# Altium schematic sheet 8051_core.SchDoc(8051_core)
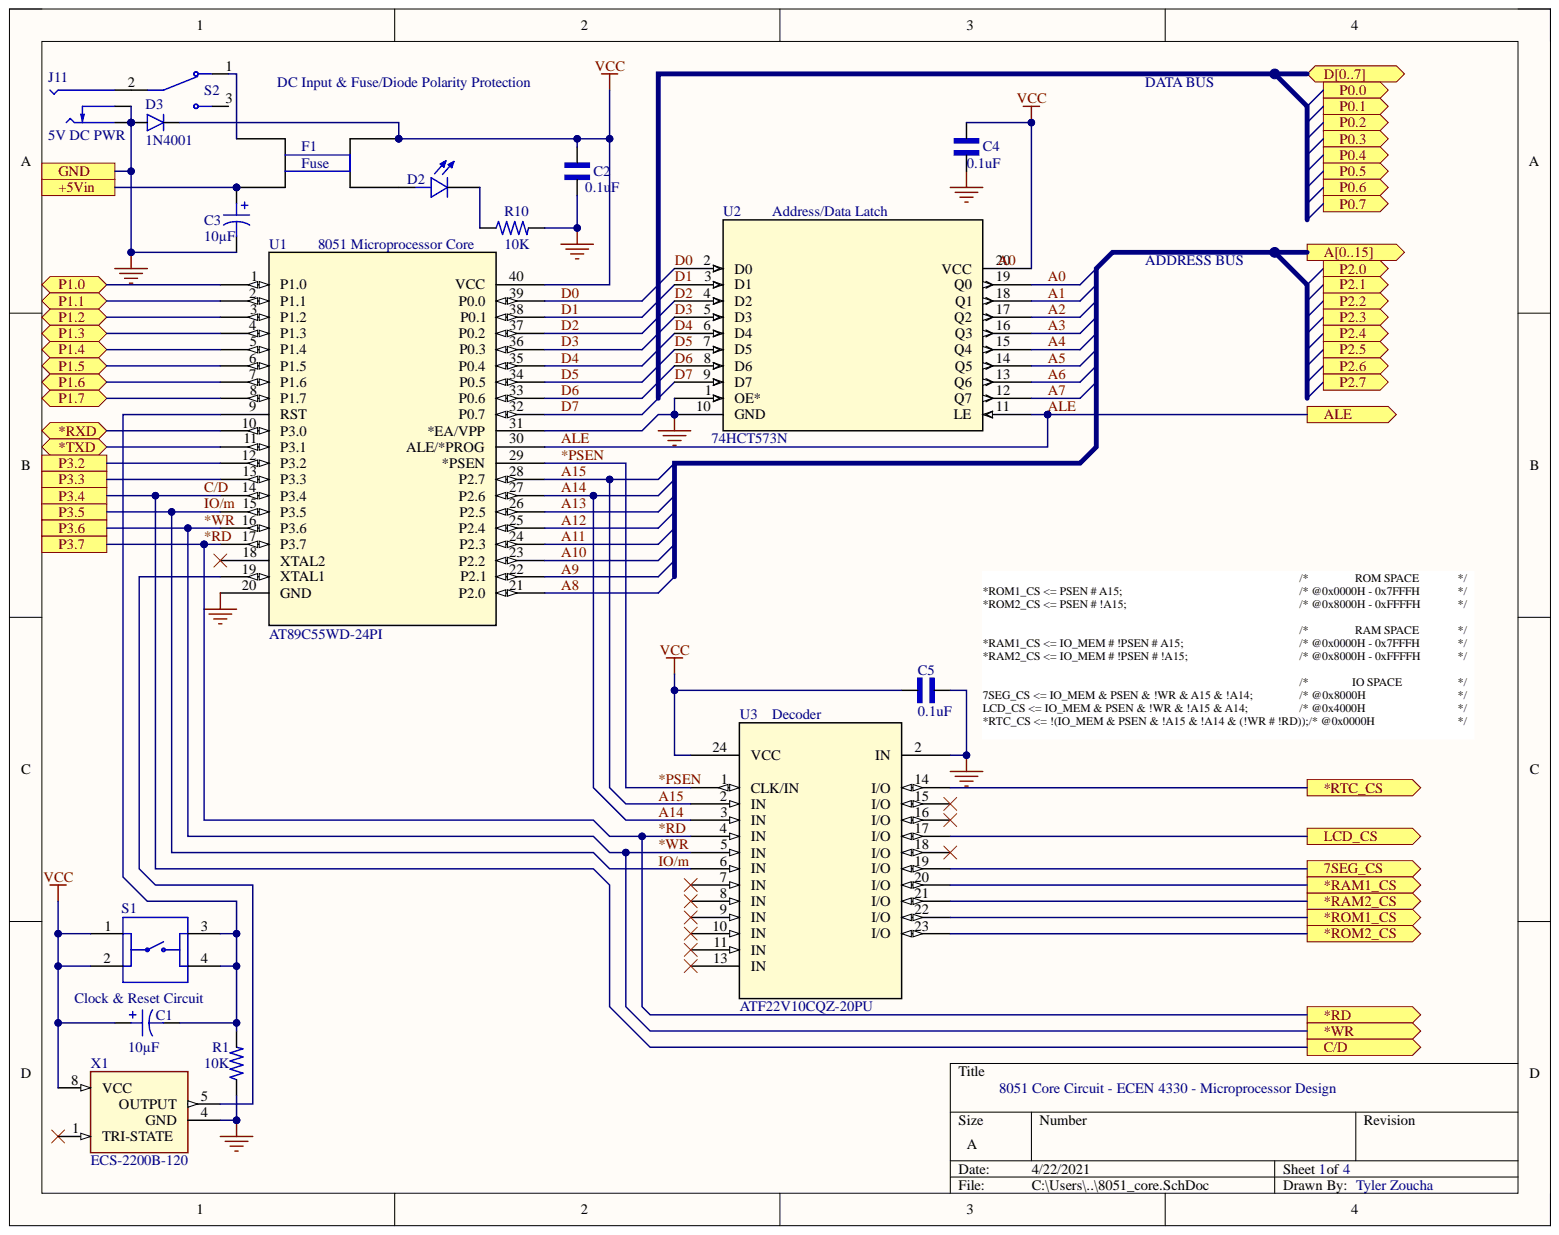
[diagram p0: 8051_core.SchDoc]

## Parts
C1  10µF  [CAP TH ALUM ELEC D5.00mm S2.50mm H12.50mm]
C2  0.1uF  [CAP_RDER73A681K2M1H03A]
C3  10µF  [CAP TH ALUM ELEC D5.00mm S2.50mm H12.50mm]
C4  0.1uF  [CAP_RDER73A681K2M1H03A]
C5  0.1uF  [CAP_RDER73A681K2M1H03A]
D2  151054RS03000  [LED_151054RS03000]  (value not drawn)
D3  1N4001  [DO41-10]
F1  Fuse  [Fuse]
J11  5V DC PWR  [SWITCHCRAFT_RAPC712X]
R1  10K  [TO508P1060X500X1900-2]
R10  10K  [TO508P1060X500X1900-2]
S1  1825910-6  [SW_1825910-6-4]  (value not drawn)
S2  100SP1T1B4M2QE  [SW_100SP1T1B4M2QE]  (value not drawn)
U1  AT89C55WD-24PI  [PDIP40_600MC_MCH]
U2  74HCT573N  [SOT146-1_NEX]
U3  ATF22V10CQZ-20PU  [24P3_ATM]
X1  ECS-2200B-120  [OSC_ECS-2200B-120]

## Ports
7SEG_CS, *RAM1_CS, *RAM2_CS, *RD, *ROM1_CS, *ROM2_CS, *RTC_CS, *RXD, *TXD, *WR, +5Vin, A0, A1, A2, A3, A4, A5, A6, A7, A8, A9, A10, A11, A12, A13, A14, A15, A[0..15], ALE, C/D, D0, D1, D2, D3, D4, D5, D6, D7, D[0..7], GND, LCD_CS, P0.0, P0.1, P0.2, P0.3, P0.4, P0.5, P0.6, P0.7, P1.0, P1.1, P1.2, P1.3, P1.4, P1.5, P1.6, P1.7, P2.0, P2.1, P2.2, P2.3, P2.4, P2.5, P2.6, P2.7, P3.2, P3.3, P3.4, P3.5, P3.6, P3.7

## Nets
VCC: C1.1 C2.2 C4.2 C5.1 D3.C F1.3 S1.1 S1.2 U1.40(VCC) U2.20(VCC) U3.24(VCC) X1.8(VCC)
7SEG_CS: U3.19(I/O) ; port 7SEG_CS
*PSEN: U1.29(*PSEN) U3.1(CLK/IN)
*RAM1_CS: U3.20(I/O) ; port *RAM1_CS
*RAM2_CS: U3.21(I/O) ; port *RAM2_CS
*RD: U1.17(P3.7) U3.4(IN) ; port *RD, port P3.7
*ROM1_CS: U3.22(I/O) ; port *ROM1_CS
*ROM2_CS: U3.23(I/O) ; port *ROM2_CS
*RTC_CS: U3.14(I/O) ; port *RTC_CS
*RXD: U1.10(P3.0) ; port *RXD
*TXD: U1.11(P3.1) ; port *TXD
*WR: U1.16(P3.6) U3.5(IN) ; port *WR, port P3.6
+5Vin: C3.1 F1.1 ; port +5Vin
A0: U2.19(Q0) ; port A[0..15]  [also labelled ]
A1: U2.18(Q1) ; port A[0..15]  [also labelled ]
A2: U2.17(Q2) ; port A[0..15]  [also labelled ]
A3: U2.16(Q3) ; port A[0..15]  [also labelled ]
A4: U2.15(Q4) ; port A[0..15]  [also labelled ]
A5: U2.14(Q5) ; port A[0..15]  [also labelled ]
A6: U2.13(Q6) ; port A[0..15]  [also labelled ]
A7: U2.12(Q7) ; port A[0..15]  [also labelled ]
A8: U1.21(P2.0) ; port A[0..15]  [also labelled ]
A9: U1.22(P2.1) ; port A[0..15]  [also labelled ]
A10: U1.23(P2.2) ; port A[0..15]  [also labelled ]
A11: U1.24(P2.3) ; port A[0..15]  [also labelled ]
A12: U1.25(P2.4) ; port A[0..15]  [also labelled ]
A13: U1.26(P2.5) ; port A[0..15]  [also labelled ]
A14: U1.27(P2.6) U3.3(IN) ; port A[0..15]  [also labelled ]
A15: U1.28(P2.7) U3.2 ; port A[0..15]  [also labelled ]
ALE: U1.30(ALE/*PROG) U2.11(LE) ; port ALE
C/D: U1.14(P3.4) ; port C/D, port P3.4
D0: U1.39(P0.0) U2.2(D0) ; port D[0..7]  [also labelled ]
D1: U1.38(P0.1) U2.3(D1) ; port D[0..7]  [also labelled ]
D2: U1.37(P0.2) U2.4(D2) ; port D[0..7]  [also labelled ]
D3: U1.36(P0.3) U2.5(D3) ; port D[0..7]  [also labelled ]
D4: U1.35(P0.4) U2.6(D4) ; port D[0..7]  [also labelled ]
D5: U1.34(P0.5) U2.7(D5) ; port D[0..7]  [also labelled ]
D6: U1.33(P0.6) U2.8(D6) ; port D[0..7]  [also labelled ]
D7: U1.32(P0.7) U2.9(D7) ; port D[0..7]  [also labelled ]
GND: C2.1 C3.2 C4.1 C5.2 D3.A J11.SHUNT J11.SLEEVE R1.1 R10.2 U1.20(GND) U1.31(*EA/VPP) U2.1(OE*) U2.10(GND) U3.2 X1.4(GND) ; port GND
IO/m: U1.15(P3.5) U3.6(IN) ; port P3.5
LCD_CS: U3.17(I/O) ; port LCD_CS
P1.0: U1.1(P1.0) ; port P1.0
P1.1: U1.2(P1.1) ; port P1.1
P1.2: U1.3(P1.2) ; port P1.2
P1.3: U1.4(P1.3) ; port P1.3
P1.4: U1.5(P1.4) ; port P1.4
P1.5: U1.6(P1.5) ; port P1.5
P1.6: U1.7(P1.6) ; port P1.6
P1.7: U1.8(P1.7) ; port P1.7
P3.2: U1.12(P3.2) ; port P3.2
P3.3: U1.13(P3.3) ; port P3.3
NetC1_2: C1.2 R1.2 S1.3 S1.4 U1.9(RST)
NetD2_A: D2.A F1.4
NetD2_C: D2.C R10.1
NetF1_2: F1.2 S2.1
NetJ11_PIN: J11.PIN S2.2
NetU1_19: U1.19(XTAL1) X1.5(OUTPUT)
N00009: ; port P0.0
N00010: ; port P0.1
N00011: ; port P0.2
N00012: ; port P0.3
N00013: ; port P0.4
N00014: ; port P0.5
N00015: ; port P0.6
N00016: ; port P0.7
N00017: ; port P2.0
N00018: ; port P2.1
N00019: ; port P2.2
N00020: ; port P2.3
N00021: ; port P2.4
N00022: ; port P2.5
N00023: ; port P2.6
N00024: ; port P2.7

Not connected: S2 3; U1 18(XTAL2); U3 7(IN) 8(IN) 9(IN) 10(IN) 11(IN) 13(IN) 15(I/O) 16(I/O) 18(I/O); X1 1(TRI-STATE)

## Library data of the parts
C1 C3 = UKT1E100MDD1TD: CAP ALUM 10UF 20% 25V RADIAL; Manufacturer: Nichicon; Database Table Name: Capacitors - Aluminum - Electrolytic TH; Lifetime @ Temp.: 1000 Hrs @ 105°C; Ripple Current @ Low Frequency: 36mA @ 120Hz; Applications: Audio; Capacitance: 10µF; Component Kind: Standard; ComponentLink1Description: Datasheet; ComponentLink1URL: http://nichicon-us.com/english/products/pdfs/e-ukt.pdf; ComponentLink2Description: DigiKey Link; ComponentLink2URL: http://digikey.com/product-detail/en/nichicon/UKT1E100MDD1TD/493-10643-1-ND/4317658; Device Package: Radial, Can; Height - Seated (Max): 0.492" (12.50mm); LastUpdated: 9/4/2020 11:29:08 PM; Lead Spacing: 0.098" (2.50mm); Library Name: Celestial Altium Library - altium_library.DbLib; Lifecycle Status: Active; Minimum Order: 1; Mounting Type: Through Hole; Operating Temperature: -55°C ~ 105°C; Package / Case: Radial, Can; Packaging: TapeAndBox; Part Number: UKT1E100MDD1TD; Part Status: Active; PartId: 22914; Price: 0.08; Series: UKT; Size / Dimension: 0.197" Dia (5.00mm); Supplier 1: DigiKey; Supplier Part Number 1: 493-10643-1-ND; Tolerance: ±20%; Value: 10µF; Voltage - Rated: 25V
C2 C4 C5 = RDER73A681K2M1H03A: Lead capacitor X7R(EIA) with Capacitance 680pF, Rated Voltage 1000Vdc; MF: Murata; Arrow_Electronics-Purchase-URL: https://snapeda.com/shop?store=Arrow+Electronics&id=4546400; Availability: Good; Check_prices: https://www.snapeda.com/parts/RDER73A681K2M1H03A/Murata/view-part/4546400/?ref=eda; Component Kind: Standard; Library Name: leadCapacitor.SchLib; Mouser-Purchase-URL: https://snapeda.com/shop?store=Mouser&id=4546400; MP: RDER73A681K2M1H03A; Package: Radial Murata; Price: None; SnapEDA_Link: https://www.snapeda.com/parts/RDER73A681K2M1H03A/Murata/view-part/4546400/?ref=snap
D2 = 151054RS03000: Red 623nm LED Indication - Discrete 1.9V Radial; MF: Wurth Electronics; Availability: Unavailable; Component Kind: Standard; Library Name: LED_red.SchLib; MP: 151054RS03000; Package: Radial WÃ¼rth Elektronik; Price: None; SnapEDA_Link: https://www.snapeda.com/parts/151054RS03000/Wurth%20Electronics%20Inc./view-part/609365/?ref=snap
D3 = 1N4001: Diode, Standard, 1a, 50v; MF: Multicomp; MP: 1N4001.; Availability: Unavailable; Component Kind: Standard; Library Name: Diode_1N4001.SchLib; Package: DO-41 Multicomp; Price: None; SnapEDA_Link: https://www.snapeda.com/parts/1N4001./Multicomp/view-part/353334/?ref=snap
J11 = RAPC712X: Power Barrel Connector Jack 2.50mm ID (0.098"), 5.50mm OD (0.217") Through Hole, Right Angle; Availability: Unavailable; Component Kind: Standard; Library Name: DC_Jack.SchLib; MP: RAPC712X; Package: None; Price: None
R1 R10 = 1-1623809-3: MPR20C 22R 5%; Availability: Unavailable; Component Kind: Standard; Library Name: RESISTOR_10k.SchLib; MP: 1-1623809-3; Package: None; Price: None
S1 = 1825910-6: Switch Push Button OFF _ON_ SPST Round Button 0.05A 24VDC Momentary Contact PC Pins Thru-Hole; Configuration_Pole-Throw: Single Pole - Single Throw; Contact_Current_Rating: 50 mA; MF: TE Connectivity; Component Kind: Standard; Digi-Key_Part_Number: 450-1650-ND; Digi-Key_Purchase_URL: https://www.digikey.com/product-detail/en/te-connectivity-alcoswitch-switches/1825910-6/450-1650-ND/1632536?utm_source=snapeda&utm_medium=aggregator&utm_campaign=symbol; EU_RoHS_Compliance: Compliant; Library Name: Switch_PushButton.SchLib; MP: 1825910-6; Package: None; SnapEDA_Link: https://www.snapeda.com/parts/1825910-6/TE%20Connectivity%20ALCOSWITCH%20Switches/view-part/424522/?ref=snap; TE_purchase_URL: https://www.te.com/usa-en/product-1825910-6.html?te_bu=Cor&te_type=disp&te_campaign=seda_glo_cor-seda-global-disp-prtnr-fy19-seda-model-bom-cta_sma-317_1&elqCampaignId=32493
S2 = 100SP1T1B4M2QE: Toggle Switch SPDT Through Hole; MF: E-Switch; Availability: Unavailable; BuyPart: https://www.snapeda.com/parts/100SP1T1B4M2QE/E-Switch/view-part/846138/?ref=eda; Component Kind: Standard; Library Name: SW_SPDT_Toggle.SchLib; MP: 100SP1T1B4M2QE; Package: None; Price: None; SnapEDA_Link: https://www.snapeda.com/parts/100SP1T1B4M2QE/E-Switch/view-part/846138/?ref=snap
U1 = AT89C55WD-24PI: No Description Available; Built_By: EMA_Molly; SourceLibrary: Microchip_2019-08-23_24; Vendor: Microchip; Component Kind: Standard; Library Name: AT89C55WD.SchLib; Manufacturer_Part_Number: AT89C55WD-24PI; RefDes: RefDes; Type: DEV; Copyright: Copyright (C) 2018 Accelerated Designs. All rights reserved
U2 = 74HCT573N: No Description Available; SourceLibrary: Nexperia_2018-05-2018; Vendor: Nexperia; Component Kind: Standard; Library Name: 74HCT573N.SchLib; Manufacturer_Part_Number: 74HCT573N; RefDes: RefDes; Type: DEV; Copyright: Copyright (C) 2016 Accelerated Designs. All rights reserved
U3 = ATF22V10CQZ-20PU: No Description Available; Built_By: EMA_UL_Team; SourceLibrary: Atmel_2015-02-13; Vendor: Atmel; Component Kind: Standard; Library Name: ATF22V10C.SchLib; Manufacturer_Part_Number: ATF22V10CQZ-20PU; RefDes: RefDes; Type: DEV; Copyright: Copyright (C) 2018 Accelerated Designs. All rights reserved
X1 = ECS-2200B-120: TL Oscillator 8-DIP, 4 Leads; Availability: Unavailable; Component Kind: Standard; Library Name: ECS-2200B-120.SchLib; MP: ECS-2200B-120; Package: None; Price: None
F1 = Fuse: Component Kind: Standard; Library Name: fuse.SchLib
